# Altium schematic sheet CAN.SchDoc(CAN)
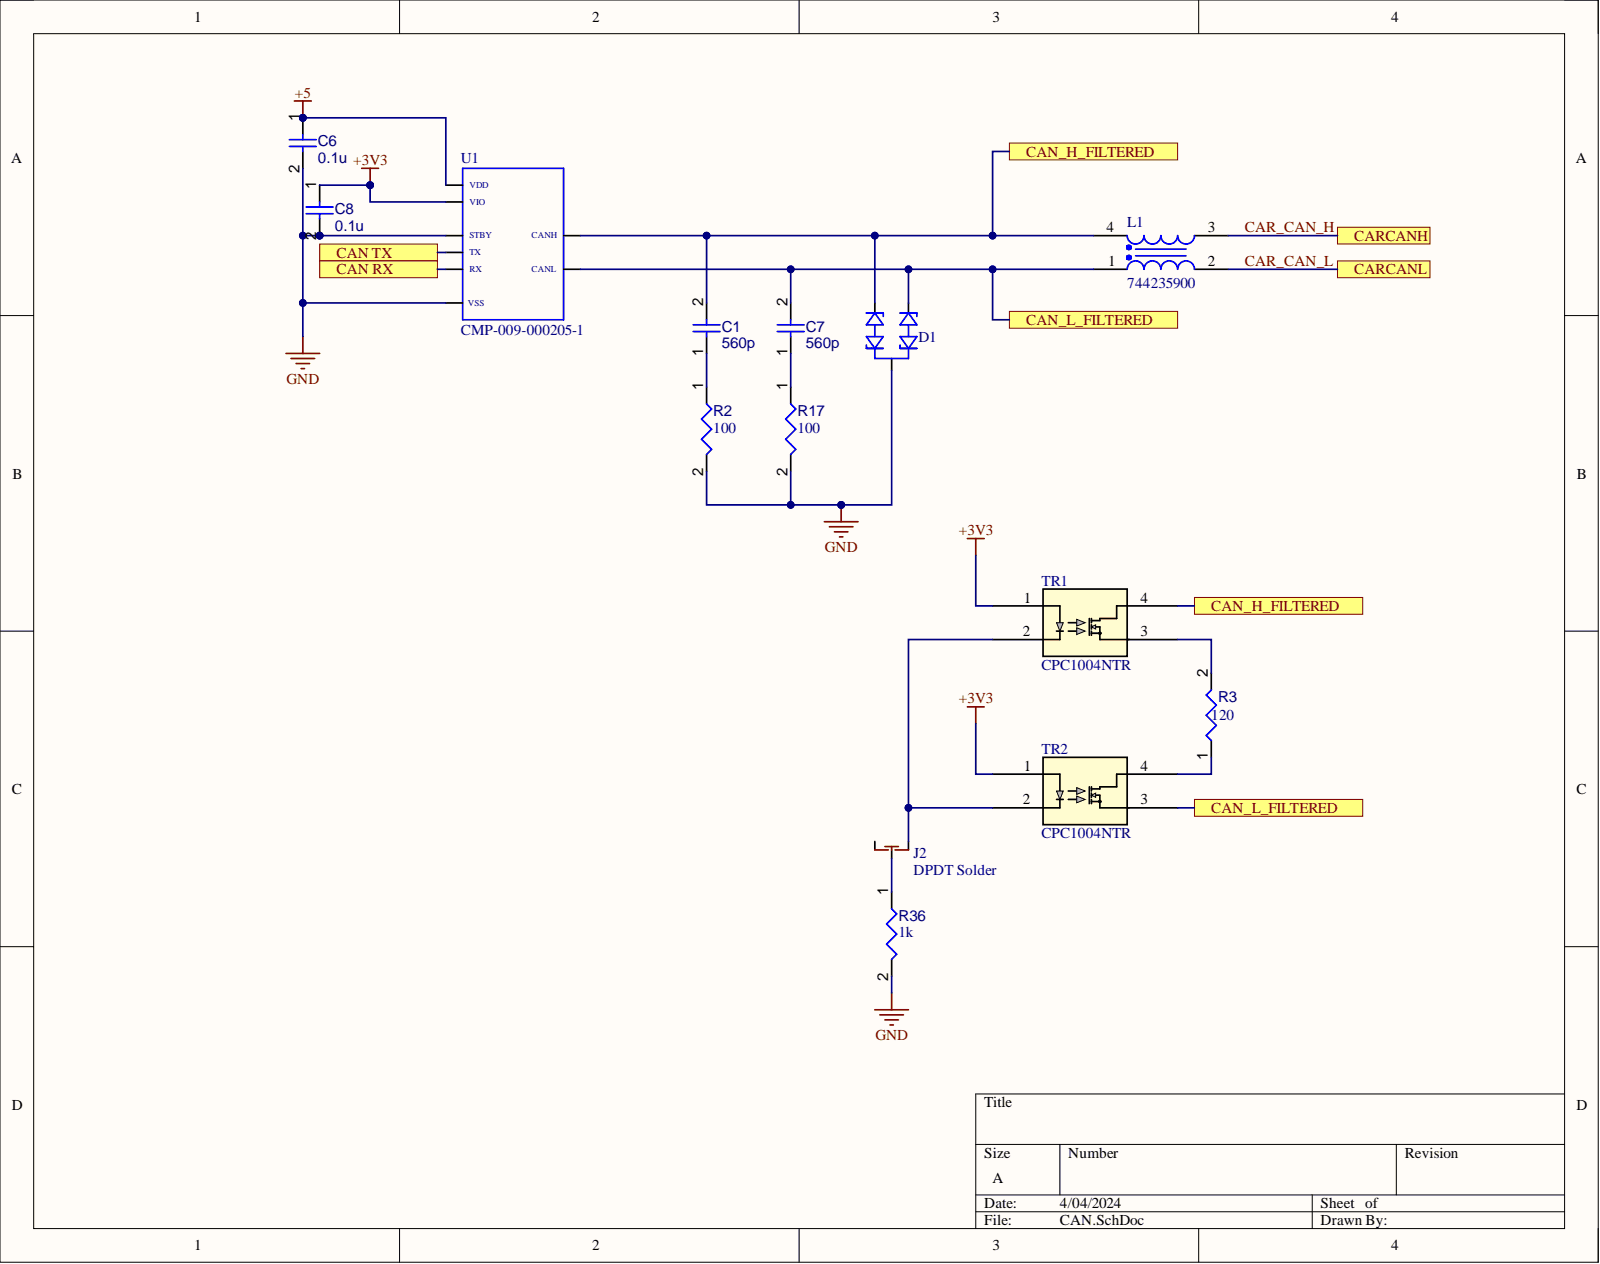
[diagram p0: CAN.SchDoc]

## Parts
C1  560p
C6  0.1u
C7  560p
C8  0.1u
D1  CMP-010-000011-1  (value not drawn)
J2  DPDT Solder
L1  744235900
R2  value 100
R3  value 120
R17  value 100
R36  value 1k
TR1  CPC1004NTR
TR2  CPC1004NTR
U1  CMP-009-000205-1

## Ports
CAN RX, CAN TX, CAN_H_FILTERED, CAN_L_FILTERED, CARCANH, CARCANL

## Nets
+3V3: C8.1 TR1.1 TR2.1 U1.5(VIO)
+5: C6.1 U1.3(VDD)
GND: C6.2 C8.2 D1.3 R2.2 R17.2 R36.2 U1.2(VSS) U1.8(STBY)
CAN RX: U1.4(RX) ; port CAN RX
CAN TX: U1.1(TX) ; port CAN TX
CAN_H_FILTERED: C1.2 D1.2 L1.4 TR1.4 U1.7(CANH) ; port CAN_H_FILTERED
CAN_L_FILTERED: C7.2 D1.1 L1.1 TR2.3 U1.6(CANL) ; port CAN_L_FILTERED
CAR_CAN_H: L1.3 ; port CARCANH
CAR_CAN_L: L1.2 ; port CARCANL
NetC1_1: C1.1 R2.1
NetC7_1: C7.1 R17.1
NetJ2_2: J2.2 TR1.2 TR2.2
NetJ2_3: J2.3 R36.1
NetR3_1: R3.1 TR2.4
NetR3_2: R3.2 TR1.3

Not connected: J2 1

## Library data of the parts
C1 C6-C8 = CMP-005-000616-1: Chip Capacitor, 2,2µF +/-20%, 10V, 0402, Thickness 0.6 mm; Available Preview Images: 2D; Component Kind: Standard; Design Item ID: CMP-001-00056-2; Source: Integrated_Library - 12.03.21 - 15.39.SchLib; Library Name: Illini Formula Electric
J2 = CMP-081-000010-1: Component Kind: Standard; Design Item ID: DPDT Solder; Source: LTC6811.SchLib; Library Name: Illini Formula Electric
L1 = CMP-052-000094-1: Data Line Filter, 2 Function(s), 60V, 2A, 4 Pin(s); Component Kind: Standard; Library Name: Illini Formula Electric
R2 R3 R17 R36 = CMP-009-000068-1: 120R 0.1W 5% 0603 (1608 Metric)  SMD; Available Preview Images: 2D; Case-EIA: 0603; Case-Metric: 1608; Component Kind: Standard; Design Item ID: CMP-009-00069-1; Source: Integrated_Library - 12.03.21 - 15.39.SchLib; Technology: SMT; Library Name: Illini Formula Electric
TR1 TR2 = CMP-149-000000-1: No Description Available; Mfr_Name: Littelfuse Inc; Component Kind: Standard; Component Type: Standard; Design Item ID: CPC1004NTR; Pin Count: 4; RefDes: RefDes; Source: CPC1004NTR.SchLib; Type: Type; Library Name: Illini Formula Electric
D1 = CMP-010-000011-1: Component Kind: Standard; Design Item ID: DIODE-SOT23_PESD1CAN; Library Name: Illini Formula Electric; Source: ife19_Elec_Library.SchLib
U1 = CMP-009-000205-1: Component Kind: Standard; Design Item ID: IC-SOIC8_MCP2562; Library Name: Illini Formula Electric; Source: ife19_Elec_Library.SchLib
